# Altium schematic sheet MCU.SchDoc(MCU)
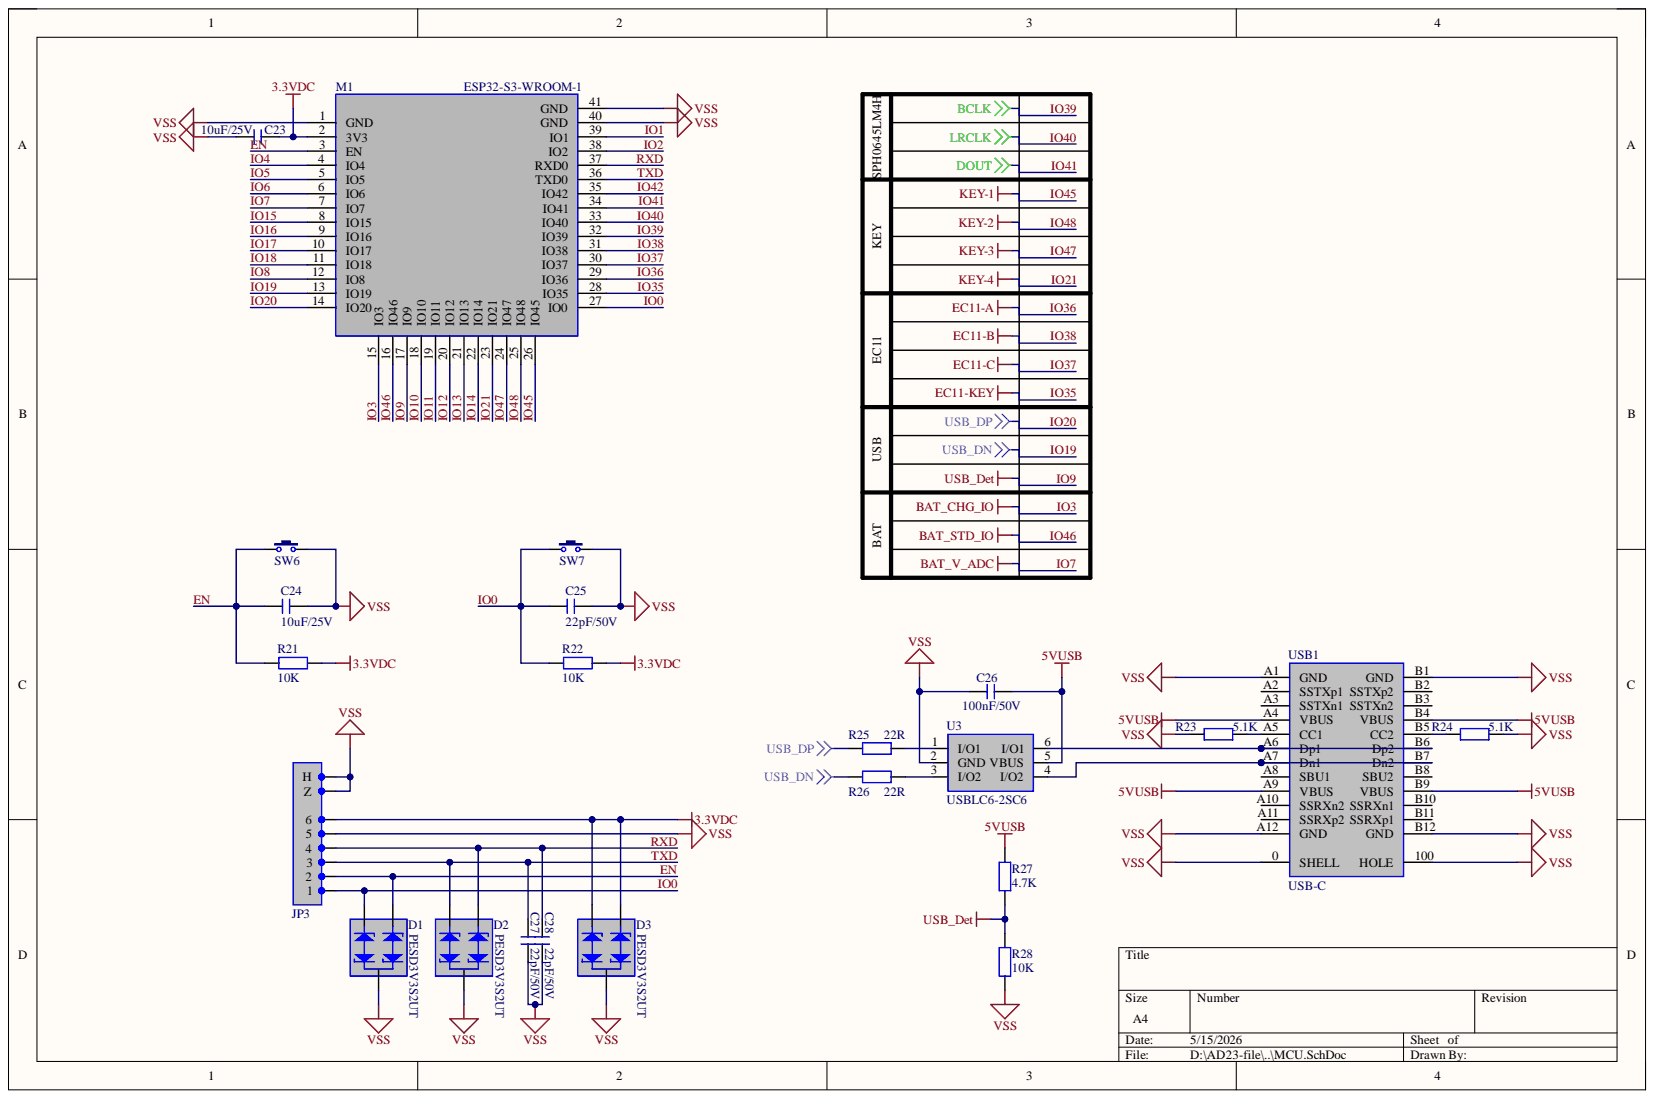
[diagram p0: MCU.SchDoc]

## Parts
C23  10uF/25V  [C 0603_L]
C24  10uF/25V  [C 0603_L]
C25  22pF/50V  [C 0603_L]
C26  100nF/50V  [C 0603_L]
C27  22pF/50V  [C 0603_L]
C28  22pF/50V  [C 0603_L]
D1  PESD3V3S2UT  [SOT23-3L]
D2  PESD3V3S2UT  [SOT23-3L]
D3  PESD3V3S2UT  [SOT23-3L]
JP3  HDR-1X6  [GH 1.25-WS-6P]  (value not drawn)
M1  ESP32-S3-WROOM-1  [ESP32-S3-WROOM-1]
R21  10K  [R 0603_L]
R22  10K  [R 0603_L]
R23  5.1K  [R 0603_L]
R24  5.1K  [R 0603_L]
R25  22R  [R 0603_L]
R26  22R  [R 0603_L]
R27  4.7K  [R 0603_L]
R28  10K  [R 0603_L]
SW6  3x6x5  [TSW SMD-3*6*2.5]  (value not drawn)
SW7  3x6x5  [TSW SMD-3*6*2.5]  (value not drawn)
U3  USBLC6-2SC6  [SOT23-6L]
USB1  USB-C  [USB-C/S_B]

## Nets
3.3VDC: C23.2 D3.1 D3.2 JP3.6 M1.2(3V3) R21.1 R22.1
5VUSB: C26.1 R27.1 U3.5(VBUS) USB1.A4(VBUS) USB1.A9(VBUS) USB1.B4(VBUS) USB1.B9(VBUS)
VSS: C23.1 C24.2 C25.2 C26.2 C27.1 C28.1 D1.3 D2.3 D3.3 JP3.0(Z) JP3.5 JP3.100(H) M1.1(GND) M1.40(GND) M1.41(GND) R23.2 R24.1 R28.2 SW6.2 SW7.2 U3.2(GND) USB1.0(SHELL) USB1.100(HOLE) USB1.A1(GND) USB1.A12(GND) USB1.B1(GND) USB1.B12(GND)
EN: C24.1 D1.1 JP3.2 M1.3(EN) R21.2 SW6.1
IO0: C25.1 D1.2 JP3.1 M1.27(IO0) R22.2 SW7.1
IO1: M1.39(IO1)
IO2: M1.38(IO2)
IO3: M1.15(IO3)
IO4: M1.4(IO4)
IO5: M1.5(IO5)
IO6: M1.6(IO6)
IO7: M1.7(IO7)
IO8: M1.12(IO8)
IO9: M1.17(IO9) R27.2 R28.1
IO10: M1.18(IO10)
IO11: M1.19(IO11)
IO12: M1.20(IO12)
IO13: M1.21(IO13)
IO14: M1.22(IO14)
IO15: M1.8(IO15)
IO16: M1.9(IO16)
IO17: M1.10(IO17)
IO18: M1.11(IO18)
IO19: M1.13(IO19) R26.1
IO20: M1.14(IO20) R25.1
IO21: M1.23(IO21)
IO35: M1.28(IO35)
IO36: M1.29(IO36)
IO37: M1.30(IO37)
IO38: M1.31(IO38)
IO39: M1.32(IO39)
IO40: M1.33(IO40)
IO41: M1.34(IO41)
IO42: M1.35(IO42)
IO45: M1.26(IO45)
IO46: M1.16(IO46)
IO47: M1.24(IO47)
IO48: M1.25(IO48)
RXD: C28.2 D2.1 JP3.4 M1.37(RXD0)
TXD: C27.2 D2.2 JP3.3 M1.36(TXD0)
NetR23_1: R23.1 USB1.A5(CC1)
NetR24_2: R24.2 USB1.B5(CC2)
NetR25_2: R25.2 U3.1(I/O1)
NetR26_2: R26.2 U3.3(I/O2)
NetU3_4: U3.4(I/O2) USB1.A7(Dn1) USB1.B7(Dn2)
NetU3_6: U3.6(I/O1) USB1.A6(Dp1) USB1.B6(Dp2)

Not connected: USB1 A2(SSTXp1) A3(SSTXn1) A8(SBU1) A10(SSRXn2) A11(SSRXp2) B2(SSTXp2) B3(SSTXn2) B8(SBU2) B10(SSRXn1) B11(SSRXp1)

## Library data of the parts
C23-C28 = C: 无极性贴片电容; 版权归属: 源创客; BOM名称: 无极性贴片电容; Component Kind: Standard; Library Name: 3.2-电容.SchLib; 学习资源: www.ooocke.com
D1-D3 = ESD_2P: 双静电放电二极管; Component Kind: Standard; Library Name: 4.1-二极管.SchLib
JP3 = Header 6: 6P接插件; 版权归属: 源创客; BOM名称: 6P接插件; Component Kind: Standard; Library Name: 14.3-接插件-脚距1.25.SchLib; 学习资源: www.ooocke.com
M1 = ESP32-S3-WROOM-1: ESP32-S3模块; Component Kind: Standard; Library Name: 11.1-模块.SchLib
R21-R28 = R: 贴片电阻; 版权归属: 源创客; BOM名称: 贴片电阻; Component Kind: Standard; Library Name: 3.1-电阻.SchLib; 学习资源: www.ooocke.com
SW6 SW7 = TSW 3x6: 3x6轻触开关; 版权归属: 源创客; BOM名称: 3x6轻触开关; Component Kind: Standard; Library Name: 6.1-按键.SchLib; 学习资源: www.ooocke.com
U3 = USBLC6-2SC6: USBESD保护器TVS陈列; 版权归属: 源创客; Component Kind: Standard; Library Name: 1.1-IC芯片.SchLib; 学习资源: www.ooocke.com
USB1 = USB-C/S: USB-C型母接口; 版权归属: 源创客; BOM名称: USB-C型母接口; Component Kind: Standard; Library Name: 14.1-接口.SchLib; 学习资源: www.ooocke.com
